# Altium schematic sheet Open Curator- Branch.SchDoc
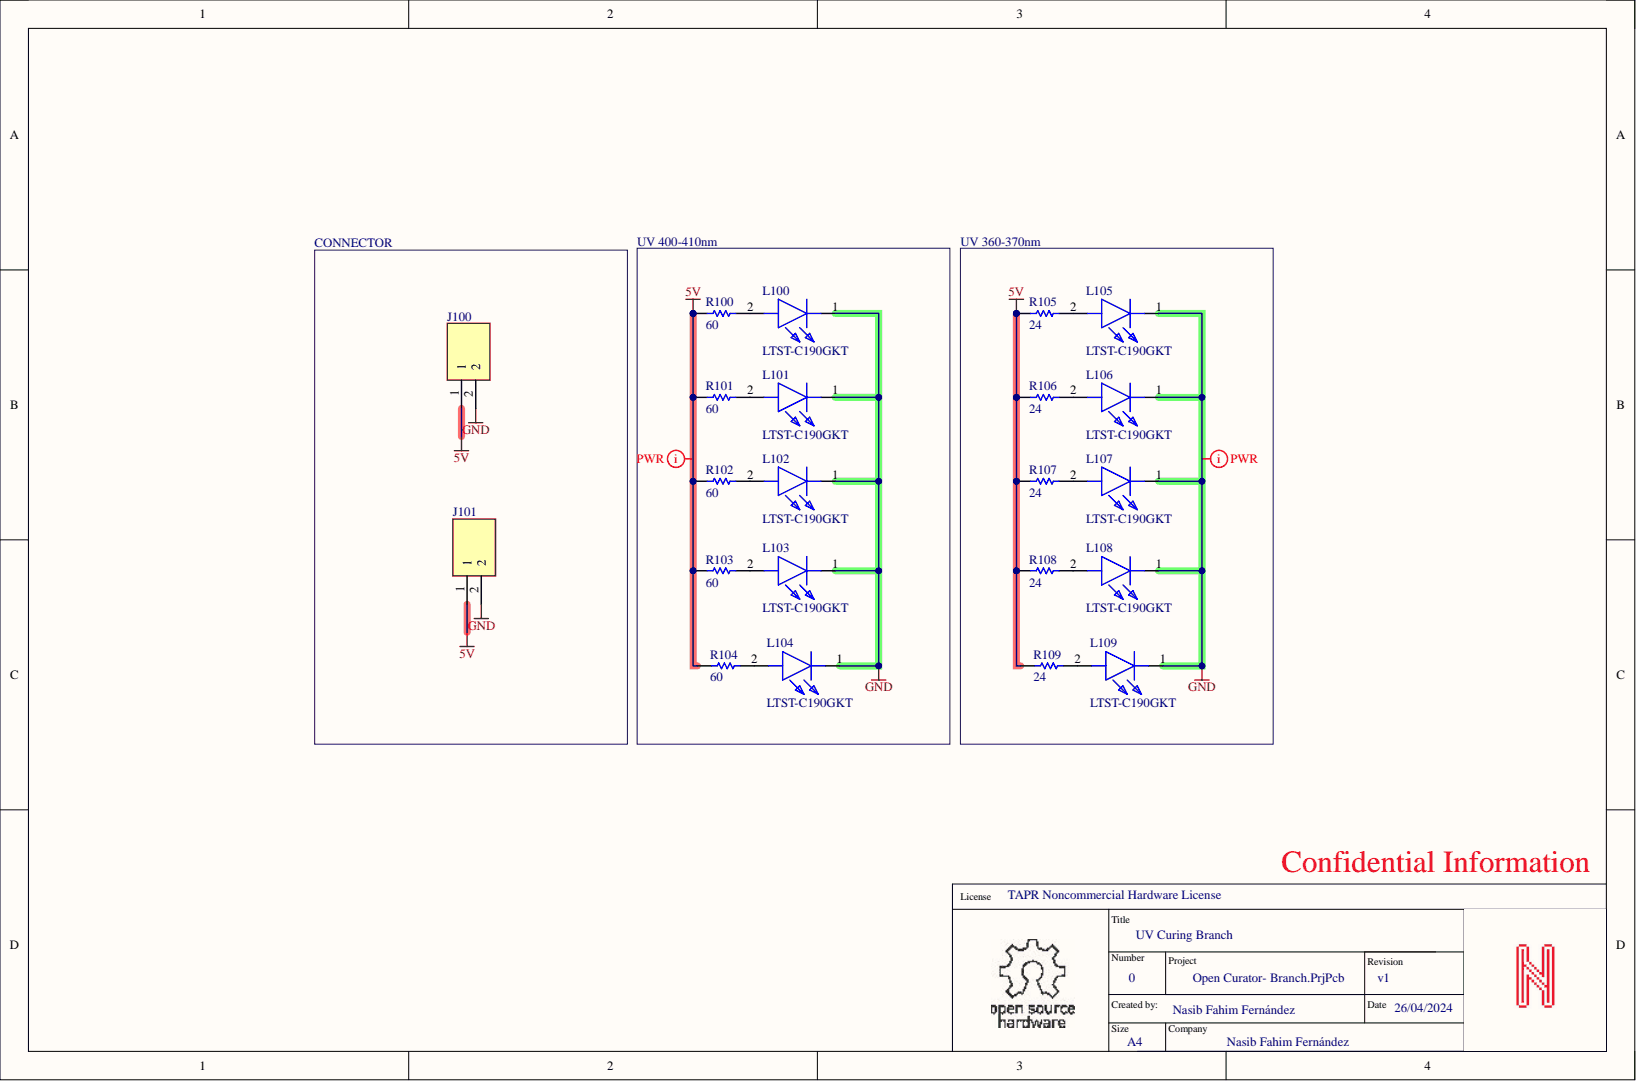
[diagram p0: Open Curator- Branch.SchDoc]

## Parts
J100  B2B-XH-AM_LF__SN_  [B2BXHAMLFSN]  (value not drawn)
J101  B2B-XH-AM_LF__SN_  [B2BXHAMLFSN]  (value not drawn)
L100  LTST-C190GKT  [VLMU3100-GS08]
L101  LTST-C190GKT  [VLMU3100-GS08]
L102  LTST-C190GKT  [VLMU3100-GS08]
L103  LTST-C190GKT  [VLMU3100-GS08]
L104  LTST-C190GKT  [VLMU3100-GS08]
L105  LTST-C190GKT  [153283367A212]
L106  LTST-C190GKT  [153283367A212]
L107  LTST-C190GKT  [153283367A212]
L108  LTST-C190GKT  [153283367A212]
L109  LTST-C190GKT  [153283367A212]
R100  60  [RESC1005X40X25LL05T05]
R101  60  [RESC1005X40X25LL05T05]
R102  60  [RESC1005X40X25LL05T05]
R103  60  [RESC1005X40X25LL05T05]
R104  60  [RESC1005X40X25LL05T05]
R105  24  [RESC1005X40X25LL05T05]
R106  24  [RESC1005X40X25LL05T05]
R107  24  [RESC1005X40X25LL05T05]
R108  24  [RESC1005X40X25LL05T05]
R109  24  [RESC1005X40X25LL05T05]

## Nets
5V: J100.1 J101.1 R100.2 R101.2 R102.2 R103.2 R104.2 R105.2 R106.2 R107.2 R108.2 R109.2
GND: J100.2 J101.2 L100.1 L101.1 L102.1 L103.1 L104.1 L105.1 L106.1 L107.1 L108.1 L109.1
NetL100_2: L100.2 R100.1
NetL101_2: L101.2 R101.1
NetL102_2: L102.2 R102.1
NetL103_2: L103.2 R103.1
NetL104_2: L104.2 R104.1
NetL105_2: L105.2 R105.1
NetL106_2: L106.2 R106.1
NetL107_2: L107.2 R107.1
NetL108_2: L108.2 R108.1
NetL109_2: L109.2 R109.1

## Library data of the parts
J100 J101 = B2B-XH-AM_LF__SN_: Connector; Manufacturer: JST (JAPAN SOLDERLESS TERMINALS); Part Number: B2B-XH-AM(LF)(SN); Arrow Part Number: B2B-XH-AM(LF)(SN); Arrow Price/Stock: https://www.arrow.com/en/products/b2b-xh-am-lf-sn/jst-manufacturing?region=europe; Component Kind: Standard; Datasheet Link: https://www.jst-mfg.com/product/pdf/eng/eXH.pdf; Height: 7mm
L100-L104 = LTST-C190GKT: SMD UV Clear Non-Diff 405nm; Manufacturer: Vishay; Component Kind: Standard; Part Number: VLMU3100-GS08
L105-L109 = LTST-C190GKT: SMD UV Clear Non-Diff 405nm; Manufacturer: Wurth Elektronik; Component Kind: Standard; Part Number: 153283367A212
R100-R104 = CMP-2002-08382-1: SMD 59 Ohms 62.5 mW 0402 1%; Manufacturer: Yageo; Part Number: RC0402FR-0759RL; Component Kind: Standard; Library Name: Altium Content Vault; Value: 60
R105-R109 = CMP-2002-08382-1: SMD 24 Ohms 62.5 mW 0402 5%; Manufacturer: Yageo; Part Number: RC0402JR-0724RL; Component Kind: Standard; Library Name: Altium Content Vault; Value: 24
